# Altium schematic sheet JX123.SchDoc(JX123)
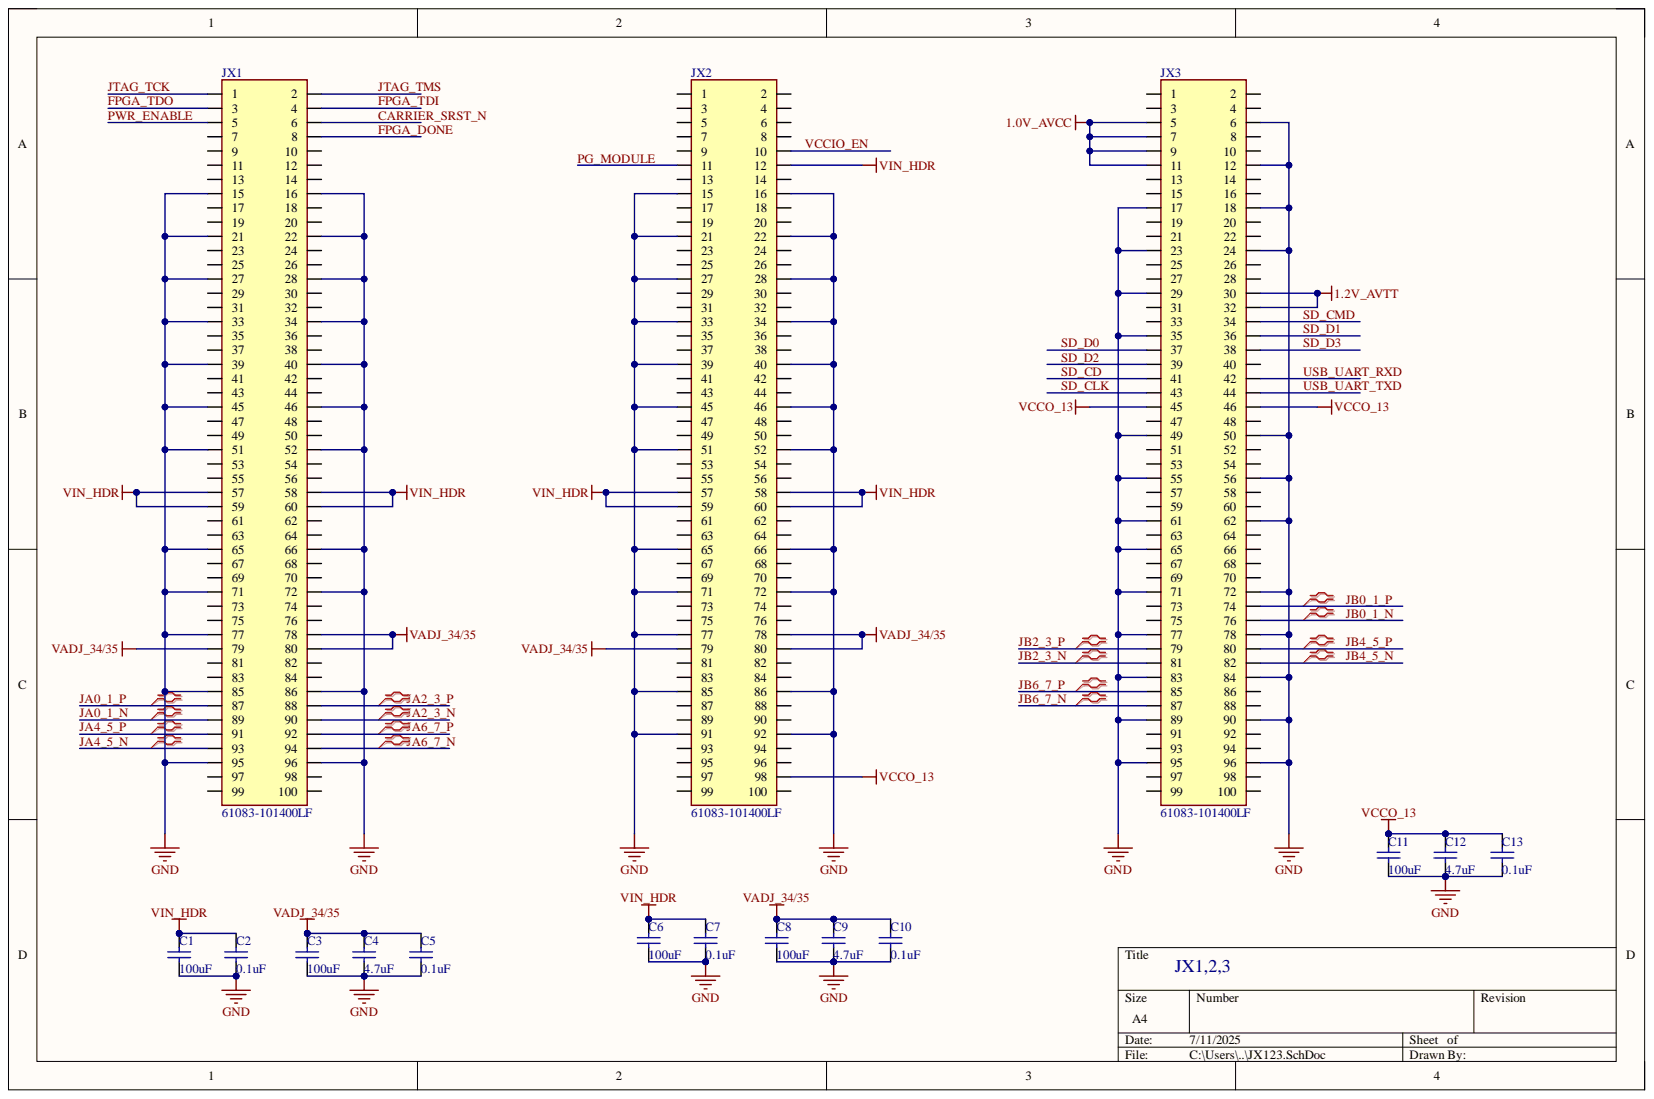
[diagram p0: JX123.SchDoc]

## Parts
C1  value 100uF  [0603_C]  (hidden comment: Cap)
C2  value 0.1uF  [0603_C]  (hidden comment: Cap)
C3  value 100uF  [0603_C]  (hidden comment: Cap)
C4  value 4.7uF  [0603_C]  (hidden comment: Cap)
C5  value 0.1uF  [0603_C]  (hidden comment: Cap)
C6  value 100uF  [0603_C]  (hidden comment: Cap)
C7  value 0.1uF  [0603_C]  (hidden comment: Cap)
C8  value 100uF  [0603_C]  (hidden comment: Cap)
C9  value 4.7uF  [0603_C]  (hidden comment: Cap)
C10  value 0.1uF  [0603_C]  (hidden comment: Cap)
C11  value 100uF  [0603_C]  (hidden comment: Cap)
C12  value 4.7uF  [0603_C]  (hidden comment: Cap)
C13  value 0.1uF  [0603_C]  (hidden comment: Cap)
JX1  61083-101400LF  [FCI_61083-101400LF]
JX2  61083-101400LF  [FCI_61083-101400LF]
JX3  61083-101400LF  [FCI_61083-101400LF]

## Nets
1.0V_AVCC: JX3.5 JX3.7 JX3.9 JX3.11
1.2V_AVTT: JX3.30 JX3.32
GND: C1.1 C2.1 C3.1 C4.1 C5.1 C6.1 C7.1 C8.1 C9.1 C10.1 C11.1 C12.1 C13.1 JX1.15 JX1.16 JX1.21 JX1.22 JX1.27 JX1.28 JX1.33 JX1.34 JX1.39 JX1.40 JX1.45 JX1.46 JX1.51 JX1.52 JX1.65 JX1.66 JX1.71 JX1.72 JX1.77 JX1.85 JX1.86 JX1.95 JX1.96 JX2.15 JX2.16 JX2.21 JX2.22 JX2.27 JX2.28 JX2.33 JX2.34 JX2.39 JX2.40 JX2.45 JX2.46 JX2.51 JX2.52 JX2.65 JX2.66 JX2.71 JX2.72 JX2.77 JX2.85 JX2.86 JX2.91 JX2.92 JX3.6 JX3.12 JX3.17 JX3.18 JX3.23 JX3.24 JX3.29 JX3.35 JX3.49 JX3.50 JX3.55 JX3.56 JX3.61 JX3.62 JX3.65 JX3.71 JX3.72 JX3.77 JX3.78 JX3.83 JX3.84 JX3.89 JX3.90 JX3.95 JX3.96
VADJ_34/35: C3.2 C4.2 C5.2 C8.2 C9.2 C10.2 JX1.78 JX1.79 JX1.80 JX2.78 JX2.79 JX2.80
VCCO_13: C11.2 C12.2 C13.2 JX2.98 JX3.45 JX3.46
VIN_HDR: C1.2 C2.2 C6.2 C7.2 JX1.57 JX1.58 JX1.59 JX1.60 JX2.12 JX2.57 JX2.58 JX2.59 JX2.60
CARRIER_SRST_N: JX1.6
FPGA_DONE: JX1.8
FPGA_TDI: JX1.4
FPGA_TDO: JX1.3
JA0_1_N: JX1.89
JA0_1_P: JX1.87
JA2_3_N: JX1.90
JA2_3_P: JX1.88
JA4_5_N: JX1.93
JA4_5_P: JX1.91
JA6_7_N: JX1.94
JA6_7_P: JX1.92
JB0_1_N: JX3.76
JB0_1_P: JX3.74
JB2_3_N: JX3.81
JB2_3_P: JX3.79
JB4_5_N: JX3.82
JB4_5_P: JX3.80
JB6_7_N: JX3.87
JB6_7_P: JX3.85
JTAG_TCK: JX1.1
JTAG_TMS: JX1.2
PG_MODULE: JX2.11
PWR_ENABLE: JX1.5
SD_CD: JX3.41
SD_CLK: JX3.43
SD_CMD: JX3.34
SD_D0: JX3.37
SD_D1: JX3.36
SD_D2: JX3.39
SD_D3: JX3.38
USB_UART_RXD: JX3.42
USB_UART_TXD: JX3.44
VCCIO_EN: JX2.10

Not connected: JX1 7 9 10 11 12 13 14 17 18 19 20 23 24 25 26 29 30 31 32 35 36 37 38 41 42 43 44 47 48 49 50 53 54 55 56 61 62 63 64 67 68 69 70 73 74 75 76 81 82 83 84 97 98 99 100; JX2 1 2 3 4 5 6 7 8 9 13 14 17 18 19 20 23 24 25 26 29 30 31 32 35 36 37 38 41 42 43 44 47 48 49 50 53 54 55 56 61 62 63 64 67 68 69 70 73 74 75 76 81 82 83 84 87 88 89 90 93 94 95 96 97 99 100; JX3 1 2 3 4 8 10 13 14 15 16 19 20 21 22 25 26 27 28 31 33 40 47 48 51 52 53 54 57 58 59 60 63 64 66 67 68 69 70 73 75 86 88 91 92 93 94 97 98 99 100

## Library data of the parts
C1-C13 = Cap: Capacitor; Component Kind: Standard; LatestRevisionDate: 17-Jul-2002; LatestRevisionNote: Re-released for DXP Platform.; Library Name: Miscellaneous Devices.IntLib; PackageDescription: Radial Cap, Thru-Hole; 2 Leads; 0.3 in Pin Spacing; PackageReference: RAD-0.3; Published: 8-Jun-2000; Signal Integrity: Cap; Simulation: CAP; Publisher: Altium Limited
JX1-JX3 = 61083-101400LF: MF: Amphenol ICC; Availability: Unavailable; Component Kind: Standard; Library Name: PCB.SchLib; MP: 61083-101400LF; Package: None; Price: None
